# Altium schematic sheet Sheet2.SchDoc(Sheet2)
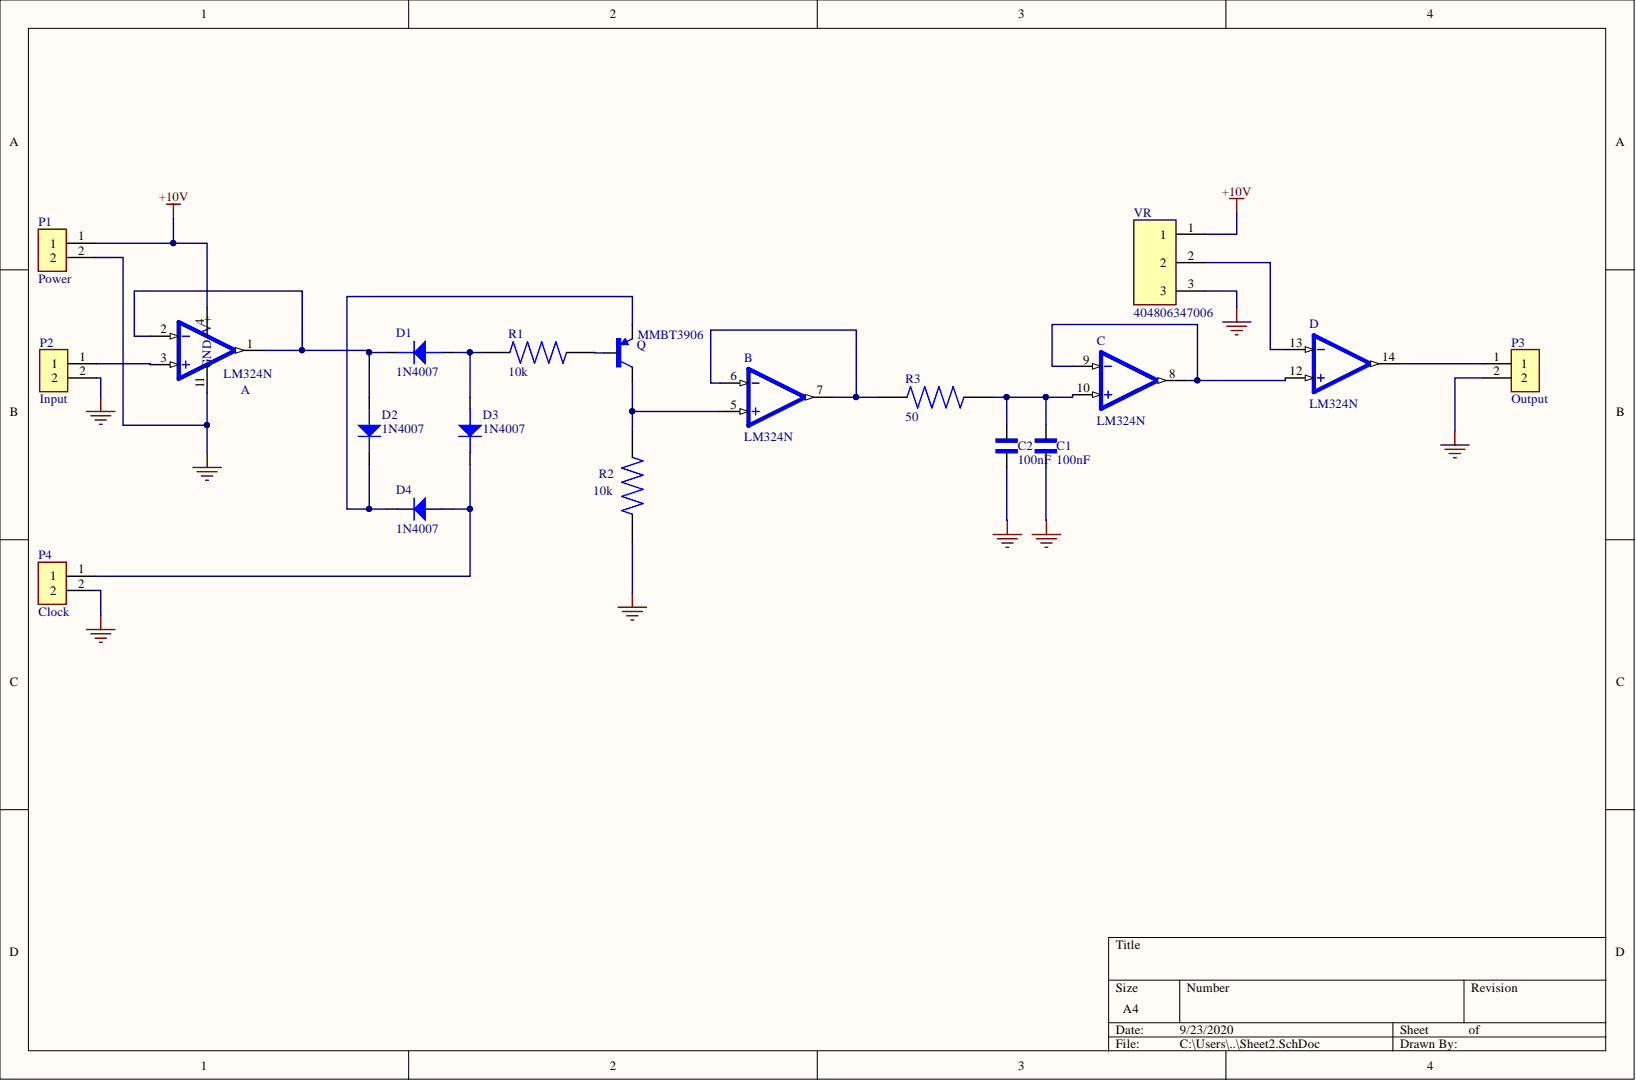
[diagram p0: Sheet2.SchDoc]

## Parts
C1  100nF  [CAPC3216X152N]
C2  100nF  [CAPC3216X152N]
D1  1N4007  [Diode 1N4007]
D2  1N4007  [Diode 1N4007]
D3  1N4007  [Diode 1N4007]
D4  1N4007  [Diode 1N4007]
P1  Power  [TE_282837-2]
P2  Input  [TE_282837-2]
P3  Output  [TE_282837-2]
P4  Clock  [TE_282837-2]
Q  MMBT3906  [SOT23]
R1  10k  [RESC3116X65N]
R2  10k  [RESC3116X65N]
R3  50  [RESC3116X65N]
VR  404806347006  [TRIM_404806347006]
  LM324N  [DIP825W47P254L1917H533Q14]  (parts A,B,C,D)

## Nets
+10V: P1.1 VR.1 .4(GND)
GND: C1.1 C2.1 P1.2 P2.2 P3.2 P4.2 R2.1 VR.3 .11
Net_1: D1.2 D2.1 .1 .2
Net_3: P2.1 .3
Net_5: Q.3 R2.2 .5
Net_6: R3.1 .6 .7
Net_8: .8 .9 .12
Net_10: C1.2 C2.2 R3.2 .10
Net_13: VR.2 .13
Net_14: P3.1 .14
NetD1_1: D1.1 D3.1 R1.1
NetD2_2: D2.2 D4.2 Q.2
NetD3_2: D3.2 D4.1 P4.1
NetQ_1: Q.1 R1.2

## Library data of the parts
C1 C2 = 12062C104KAT2A: 0.1ÂµF Â±10% 200V Ceramic Capacitor X7R 1206 (3216 Metric); MF: AVX; Arrow_Americas-Purchase-URL: https://snapeda.com/shop?store=Arrow+Americas&id=607128; Availability: Unavailable; Component Kind: Standard; DigiKey-Purchase-URL: https://snapeda.com/shop?store=DigiKey&id=607128; Library Name: 12062C104KAT2A.SchLib; Mouser-Purchase-URL: https://snapeda.com/shop?store=Mouser&id=607128; MP: 12062C104KAT2A; Package: 3216 AVX; Price: None; SnapEDA_Link: https://www.snapeda.com/parts/12062C104KAT2A/AVX/view-part/607128/?ref=snap
D1-D4 = 1N4007FLTR: Manufacturer 1: Sangdest Microelectronics; Category: Diodes; Component Kind: Standard; Library Name: Schlib1.SchLib; Manufacturer Part Number 1: 1N4007FLTR; Pricing 1: 1=0.10000, 10=0.06900, 25=0.06600, 100=0.02900, 250=0.02788, 500=0.02280, 1000=0.01584 (USD); RoHS: No; Stock 1: 1367; Supplier 1: Digi-Key; Supplier Part Number 1: 1655-1N4007FLCT-ND
P1-P4 = 282837-2: Connector Terminal Blocks Receptacle 2 Position 5.08mm Solder Straight Thru-Hole 13.5A/Contact; MF: TE Connectivity; Component Kind: Standard; Digi-Key_Part_Number: A113320-ND; Digi-Key_Purchase_URL: https://www.digikey.in/product-detail/en/te-connectivity-amp-connectors/282837-2/A113320-ND/2187973?utm_source=snapeda&utm_medium=aggregator&utm_campaign=symbol; Library Name: 282837-2.IntLib; MP: 282837-2; Package: None; SnapEDA_Link: https://www.snapeda.com/parts/282837-2/TE%20Connectivity%20AMP%20Connectors/view-part/2549980/?ref=snap; TE_purchase_URL: https://www.te.com/usa-en/product-282837-2.html?te_bu=Cor&te_type=disp&te_campaign=seda_glo_cor-seda-global-disp-prtnr-fy19-seda-model-bom-cta_sma-317_1&elqCampaignId=32493
Q = MMBT3906: Bipolar _BJT_ Transistor; MF: STMicroelectronics; Availability: Unavailable; Component Kind: Standard; Library Name: MMBT3906.IntLib; MP: MMBT3906; Package: SOT-23 ON Semiconductor; Price: None; SnapEDA_Link: https://www.snapeda.com/parts/MMBT3906/STMicroelectronics/view-part/2548435/?ref=snap
R1-R3 = RC1206JR-0713RL: 13 Ohms Â±5% 0.25W, 1/4W Chip Resistor 1206 _3216 Metric_ Moisture Resistant Thick Film; MF: Yageo; Availability: Unavailable; Component Kind: Standard; Library Name: RC1206JR-0713RL.IntLib; MP: RC1206JR-0713RL; Package: 3116 Yageo; Price: None; SnapEDA_Link: https://www.snapeda.com/parts/RC1206JR-0713RL/Yageo/view-part/105893/?ref=snap
VR = 404806347006: 500 Ohms 0.5W, 1/2W PC Pins Through Hole Trimmer Potentiometer Cermet 1 Turn Top Adjustment; MF: TE Connectivity; Availability: Unavailable; Component Kind: Standard; Library Name: potentiometer.IntLib; MP: 404806347006; Package: 6332 TE Connectivity; Price: None; SnapEDA_Link: https://www.snapeda.com/parts/404806347006/TE%20Connectivity%20Passive%20Product/view-part/1462311/?ref=snap
 = LM324N/NOPB: 4-Channel industry standard operational amplifier 14-PDIP 0 to 70; MF: Texas Instruments; Arrow_Asia-Purchase-URL: https://snapeda.com/shop?store=Arrow+Asia&id=295; Availability: Good; Component Kind: Standard; DigiKey-Purchase-URL: https://snapeda.com/shop?store=DigiKey&id=295; Library Name: LM324N_NOPB.IntLib; Mouser-Purchase-URL: https://snapeda.com/shop?store=Mouser&id=295; MP: LM324N; Package: PDIP-14 Texas Instruments; Price: None; SnapEDA_Link: https://www.snapeda.com/parts/LM324N/Texas%20Instruments/view-part/295/?ref=snap; Texas_Instruments-Purchase-URL: https://snapeda.com/shop?store=Texas+Instruments&id=295
